# Altium schematic sheet MCU & RF PADSPADS.SchDoc("MCU & RF PADSPADS")
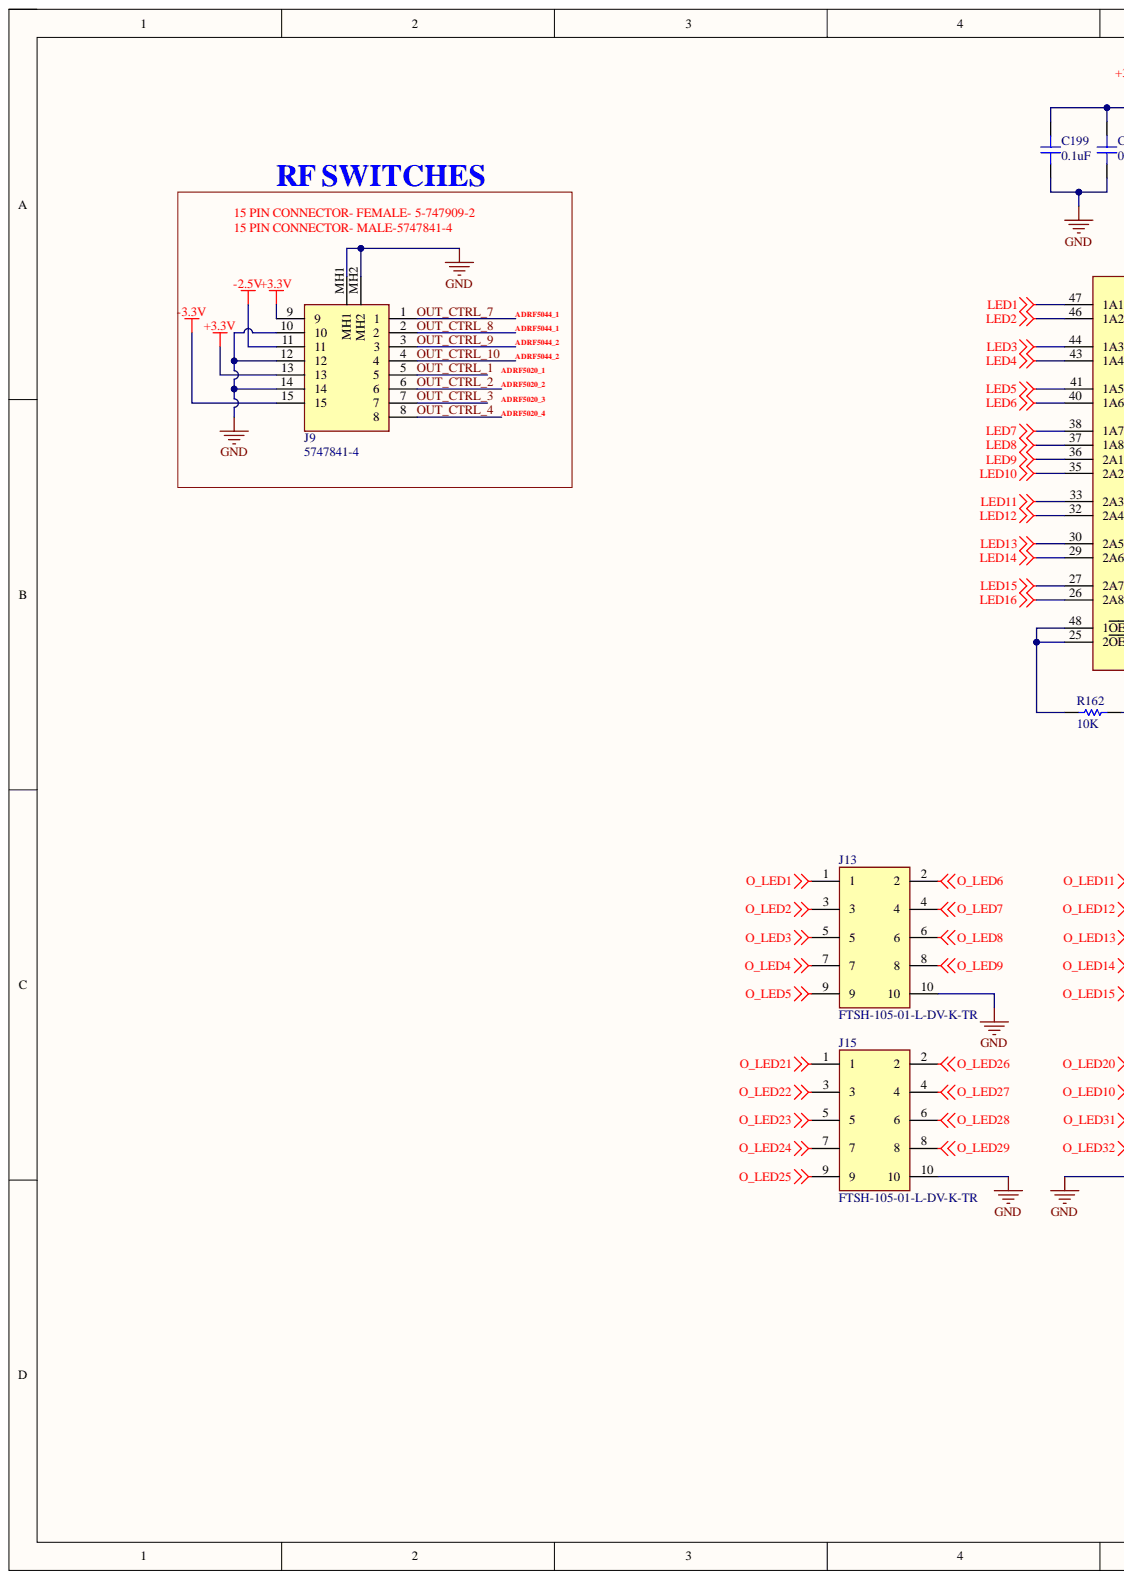
[diagram p0: MCU & RF PADSPADS.SchDoc, left]
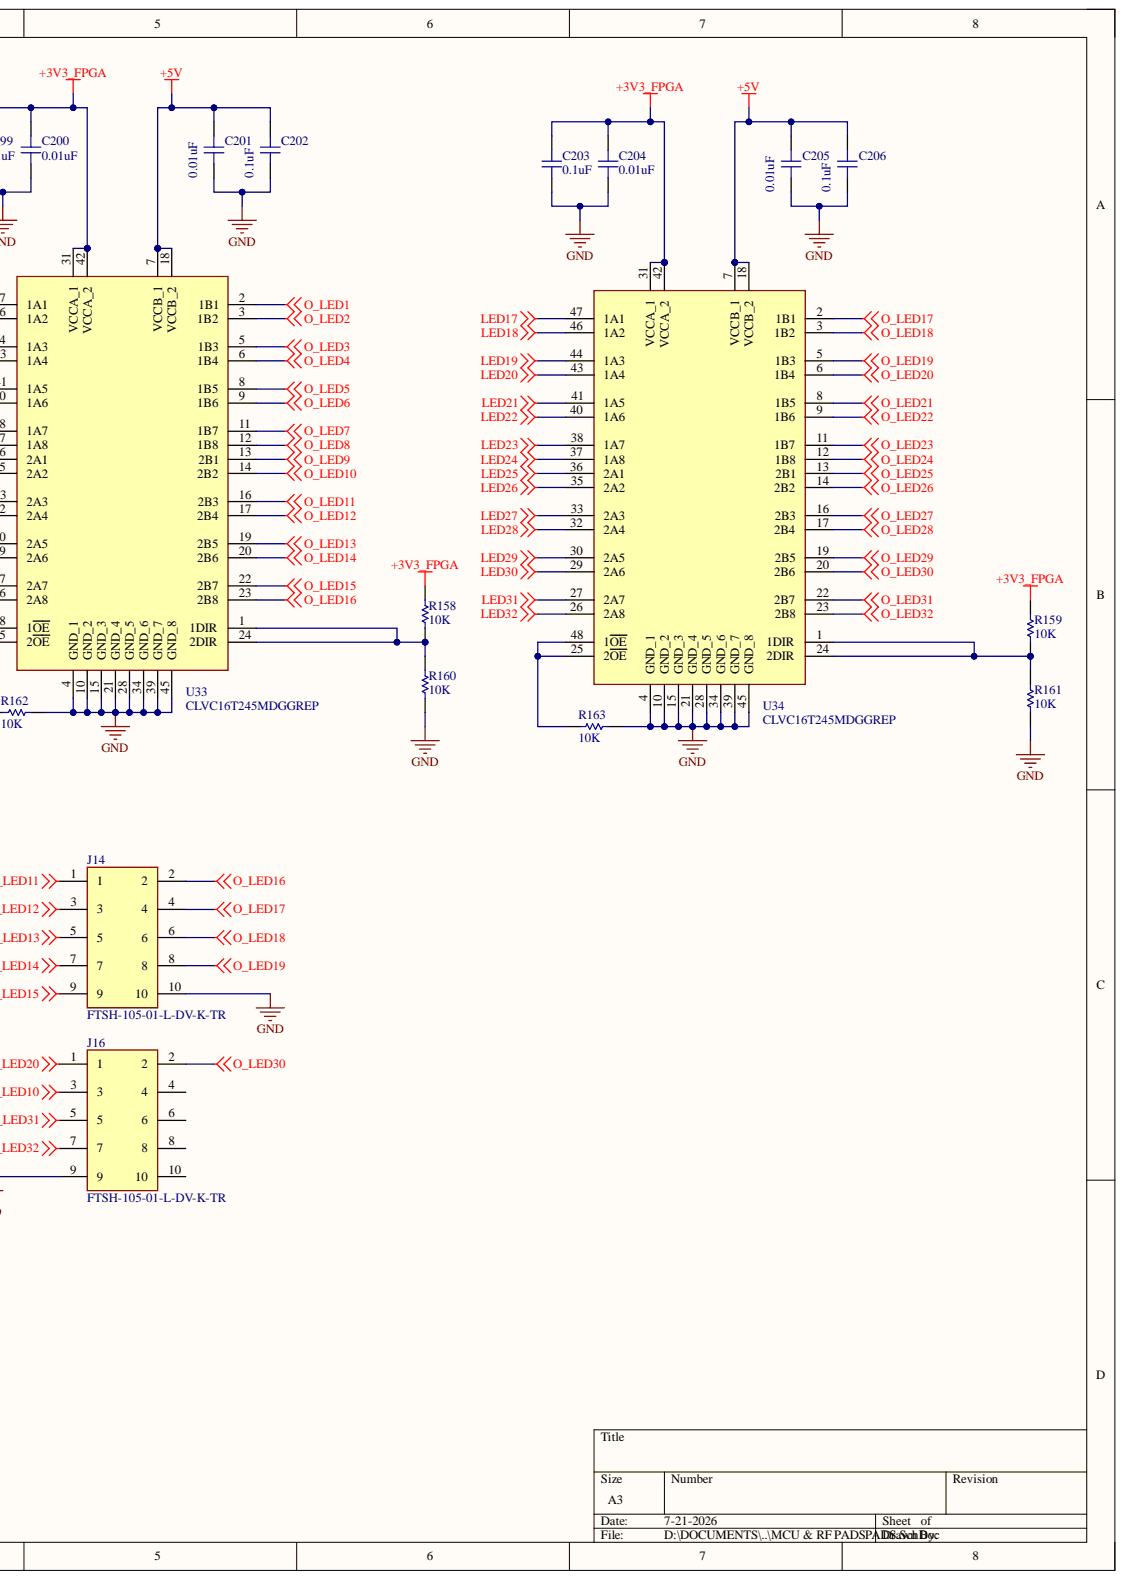
[diagram p1: MCU & RF PADSPADS.SchDoc, right]

## Parts
C199  0.1uF  [CAP_0402]
C200  0.01uF  [CAP_0402]
C201  0.01uF  [CAP_0402]
C202  0.1uF  [CAP_0402]
C203  0.1uF  [CAP_0402]
C204  0.01uF  [CAP_0402]
C205  0.01uF  [CAP_0402]
C206  0.1uF  [CAP_0402]
J9  5747841-4  [57478414]
J13  FTSH-105-01-L-DV-K-TR  [FTSH10501LDVKTR]
J14  FTSH-105-01-L-DV-K-TR  [FTSH10501LDVKTR]
J15  FTSH-105-01-L-DV-K-TR  [FTSH10501LDVKTR]
J16  FTSH-105-01-L-DV-K-TR  [FTSH10501LDVKTR]
R158  10K  [RES_0402]
R159  10K  [RES_0402]
R160  10K  [RES_0402]
R161  10K  [RES_0402]
R162  10K  [RES_0402]
R163  10K  [RES_0402]
U33  CLVC16T245MDGGREP  [SOP50P810X120-48N]
U34  CLVC16T245MDGGREP  [SOP50P810X120-48N]

## Nets
+3.3V: J9.9 J9.13
+3V3_FPGA: C199.2 C200.2 C203.2 C204.2 R158.2 R159.2 U33.31(VCCA_1) U33.42(VCCA_2) U34.31(VCCA_1) U34.42(VCCA_2)
+5V: C201.2 C202.2 C205.2 C206.2 U33.7(VCCB_1) U33.18(VCCB_2) U34.7(VCCB_1) U34.18(VCCB_2)
-2.5V: J9.11
-3.3V: J9.15
GND: C199.1 C200.1 C201.1 C202.1 C203.1 C204.1 C205.1 C206.1 J9.10 J9.12 J9.14 J9.MH1 J9.MH2 J13.10 J14.10 J15.10 J16.9 R160.1 R161.1 R162.1 R163.1 U33.4(GND_1) U33.10(GND_2) U33.15(GND_3) U33.21(GND_4) U33.28(GND_5) U33.34(GND_6) U33.39(GND_7) U33.45(GND_8) U34.4(GND_1) U34.10(GND_2) U34.15(GND_3) U34.21(GND_4) U34.28(GND_5) U34.34(GND_6) U34.39(GND_7) U34.45(GND_8)
LED1: U33.47(1A1)
LED2: U33.46(1A2)
LED3: U33.44(1A3)
LED4: U33.43(1A4)
LED5: U33.41(1A5)
LED6: U33.40(1A6)
LED7: U33.38(1A7)
LED8: U33.37(1A8)
LED9: U33.36(2A1)
LED10: U33.35(2A2)
LED11: U33.33(2A3)
LED12: U33.32(2A4)
LED13: U33.30(2A5)
LED14: U33.29(2A6)
LED15: U33.27(2A7)
LED16: U33.26(2A8)
LED17: U34.47(1A1)
LED18: U34.46(1A2)
LED19: U34.44(1A3)
LED20: U34.43(1A4)
LED21: U34.41(1A5)
LED22: U34.40(1A6)
LED23: U34.38(1A7)
LED24: U34.37(1A8)
LED25: U34.36(2A1)
LED26: U34.35(2A2)
LED27: U34.33(2A3)
LED28: U34.32(2A4)
LED29: U34.30(2A5)
LED30: U34.29(2A6)
LED31: U34.27(2A7)
LED32: U34.26(2A8)
O_LED1: J13.1 U33.2(1B1)
O_LED2: J13.3 U33.3(1B2)
O_LED3: J13.5 U33.5(1B3)
O_LED4: J13.7 U33.6(1B4)
O_LED5: J13.9 U33.8(1B5)
O_LED6: J13.2 U33.9(1B6)
O_LED7: J13.4 U33.11(1B7)
O_LED8: J13.6 U33.12(1B8)
O_LED9: J13.8 U33.13(2B1)
O_LED10: J16.3 U33.14(2B2)
O_LED11: J14.1 U33.16(2B3)
O_LED12: J14.3 U33.17(2B4)
O_LED13: J14.5 U33.19(2B5)
O_LED14: J14.7 U33.20(2B6)
O_LED15: J14.9 U33.22(2B7)
O_LED16: J14.2 U33.23(2B8)
O_LED17: J14.4 U34.2(1B1)
O_LED18: J14.6 U34.3(1B2)
O_LED19: J14.8 U34.5(1B3)
O_LED20: J16.1 U34.6(1B4)
O_LED21: J15.1 U34.8(1B5)
O_LED22: J15.3 U34.9(1B6)
O_LED23: J15.5 U34.11(1B7)
O_LED24: J15.7 U34.12(1B8)
O_LED25: J15.9 U34.13(2B1)
O_LED26: J15.2 U34.14(2B2)
O_LED27: J15.4 U34.16(2B3)
O_LED28: J15.6 U34.17(2B4)
O_LED29: J15.8 U34.19(2B5)
O_LED30: J16.2 U34.20(2B6)
O_LED31: J16.5 U34.22(2B7)
O_LED32: J16.7 U34.23(2B8)
OUT_CTRL_1: J9.5
OUT_CTRL_2: J9.6
OUT_CTRL_3: J9.7
OUT_CTRL_4: J9.8
OUT_CTRL_7: J9.1
OUT_CTRL_8: J9.2
OUT_CTRL_9: J9.3
OUT_CTRL_10: J9.4
NetR158_1: R158.1 R160.2 U33.1(1DIR) U33.24(2DIR)
NetR159_1: R159.1 R161.2 U34.1(1DIR) U34.24(2DIR)
NetR162_2: R162.2 U33.25(2OE) U33.48(1OE)
NetR163_2: R163.2 U34.25(2OE) U34.48(1OE)

Not connected: J16 4 6 8 10

## Library data of the parts
C199-C206 = CAP_0402_50V: C0402_50V; Component Kind: Standard; Library Name: FPGA_LIB.SchLib
J9 = 5747841-4: Body Features: Mating Retention Feature Material Zinc; Manufacturer_Name: TE Connectivity; Geometry.Height: 12.93mm; Component Kind: Standard; Datasheet Link: https://www.te.com/commerce/DocumentDelivery/DDEController?Action=srchrtrv&DocNm=82068_AMPLIMITE_Right-Angle_Posted_Conn&DocType=Catalog%20Section&DocLang=English&PartCntxt=5747841-4&DocFormat=pdf; Library Name: MAX10.SchLib; Manufacturer_Part_Number: 5747841-4; Mouser Part Number: 571-5747841-4; Mouser Price/Stock: https://www.mouser.co.uk/ProductDetail/TE-Connectivity/5747841-4?qs=x6EjVpvqMVOlwfI9MwrN2A%3D%3D
J13-J16 = FTSH-105-01-L-DV-K-TR: Samtec, FTSH, 10 Way, 2 Row, Straight Pin Header; Geometry.Height: 6.1mm; Component Kind: Standard; Datasheet Link: https://suddendocs.samtec.com/prints/ftsh-1xx-xx-xxx-dv-xxx-xxx-x-xx-mkt.pdf; Library Name: MAX10.SchLib; Manufacturer_Name: SAMTEC; Manufacturer_Part_Number: FTSH-105-01-L-DV-K-TR; Mouser Part Number: 200-FTSH10501LDVKTR; Mouser Price/Stock: https://www.mouser.co.uk/ProductDetail/Samtec/FTSH-105-01-L-DV-K-TR?qs=fnpEE5GccuNZAxvMlxrNVQ%3D%3D
R158-R163 = RES_0402: R0402; Component Kind: Standard
  R158-R161: Library Name: MAX10.SchLib
  R162 R163: Library Name: FPGA_LIB.SchLib
U33 U34 = CLVC16T245MDGGREP: IC; Manufacturer_Name: Texas Instruments; Component Kind: Standard; Datasheet Link: http://www.ti.com/lit/gpn/sn74lvc16t245-ep; Height: 1.2mm; Library Name: FPGA_LIB.SchLib; Manufacturer_Part_Number: CLVC16T245MDGGREP; Mouser Part Number: 595-CLC16T245MDGGREP; Mouser Price/Stock: https://www.mouser.co.uk/ProductDetail/Texas-Instruments/CLVC16T245MDGGREP?qs=c1nyi2h1TsquyUDOPWgPnw%3D%3D
